# Altium schematic sheet JTAG.SchDoc(JTAG)
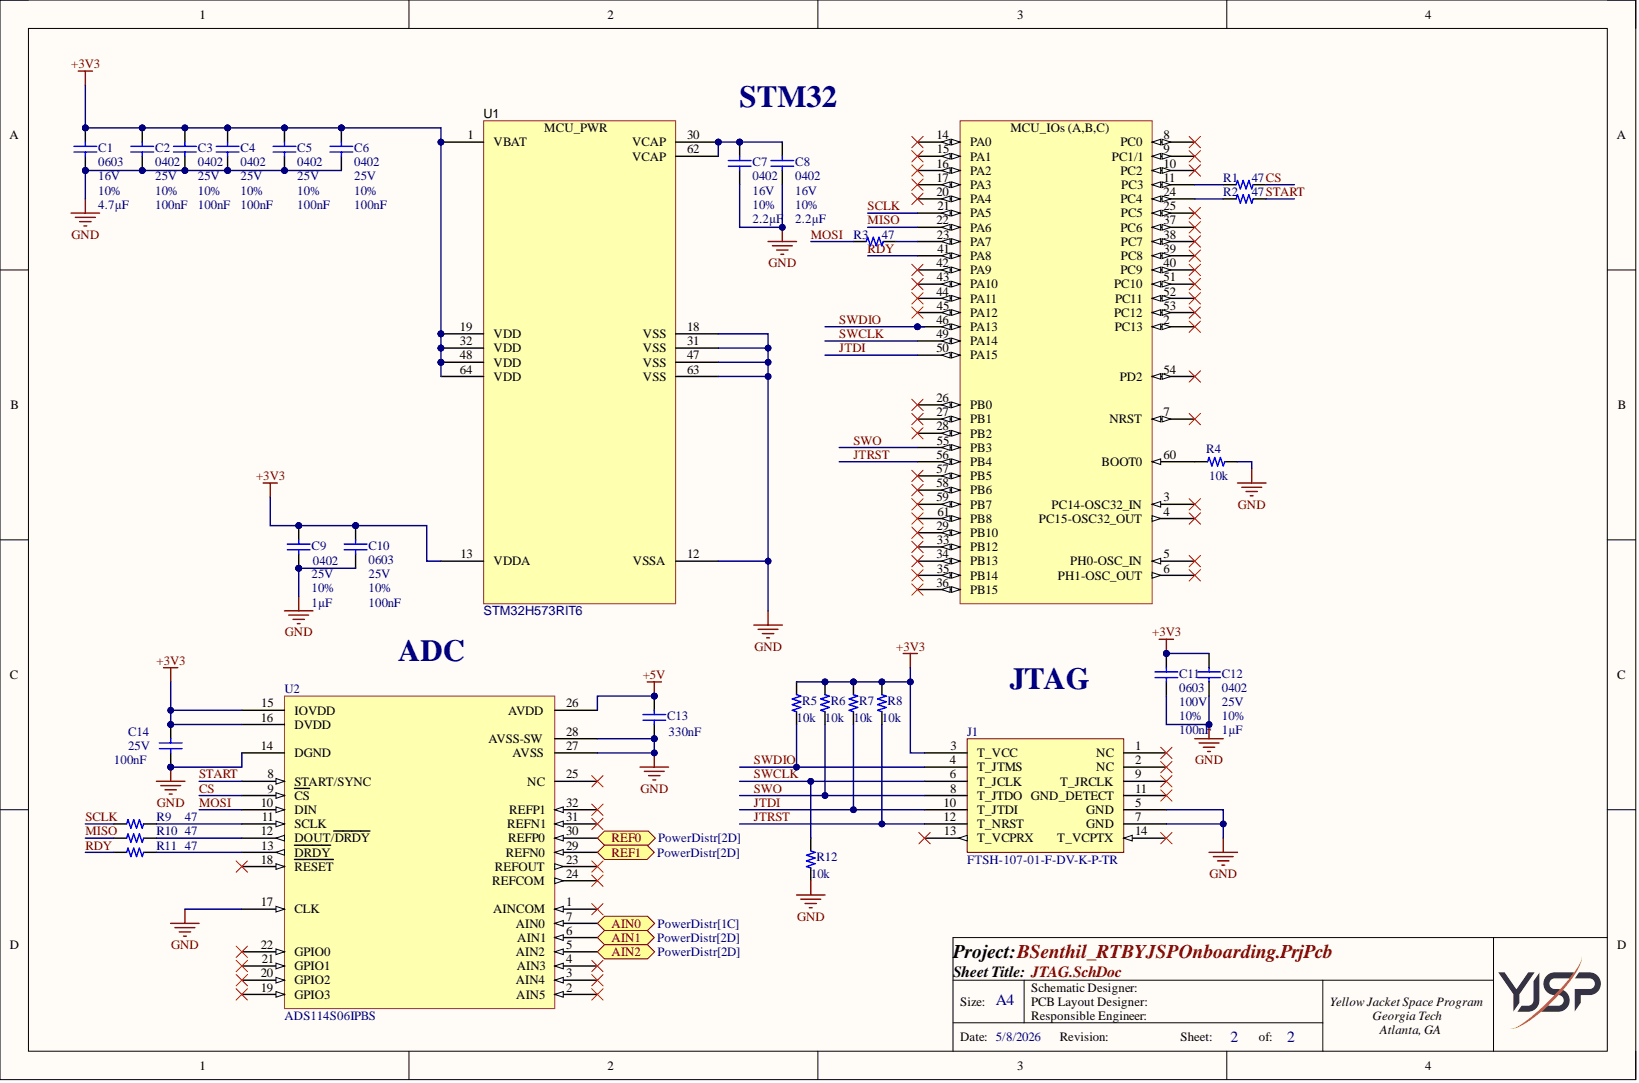
[diagram p0: JTAG.SchDoc]

## Parts
C1  Package: 0603  Tolerance: 10%  Voltage Rating: 16V  (hidden comment: GRM188Z71C475KE21J)
C2  Package: 0402  Tolerance: 10%  Voltage Rating: 25V  (hidden comment: GRM155R71E104KE14J)
C3  Package: 0402  Tolerance: 10%  Voltage Rating: 25V  (hidden comment: GRM155R71E104KE14J)
C4  Package: 0402  Tolerance: 10%  Voltage Rating: 25V  (hidden comment: GRM155R71E104KE14J)
C5  Package: 0402  Tolerance: 10%  Voltage Rating: 25V  (hidden comment: GRM155R71E104KE14J)
C6  Package: 0402  Tolerance: 10%  Voltage Rating: 25V  (hidden comment: GRM155R71E104KE14J)
C7  Package: 0402  Voltage Rating: 16V  (hidden comment: GRM155R61C225KE11D)
C8  Package: 0402  Voltage Rating: 16V  (hidden comment: GRM155R61C225KE11D)
C9  Package: 0402  Tolerance: 10%  Voltage Rating: 25V  (hidden comment: GRM155C81E105KE11D)
C10  Package: 0603  Tolerance: 10%  Voltage Rating: 25V  (hidden comment: C1608X8R1E104K080AE)
C11  Package: 0603  Tolerance: 10%  Voltage Rating: 100V  (hidden comment: CL10B104KC8NNNC)
C12  Package: 0402  Tolerance: 10%  Voltage Rating: 25V  (hidden comment: GRM155C81E105KE11D)
C13  Capacitance: 330nF  (hidden comment: CGA3E3X7R1E334M080AB)
C14  Capacitance: 100nF  Voltage Rating: 25V  (hidden comment: C1608X8R1E104K080AE)
J1  FTSH-107-01-F-DV-K-P-TR
R1  (no value)
R2  (no value)
R3  (no value)
R4  Resistance: 10k
R5  Resistance: 10k
R6  Resistance: 10k
R7  Resistance: 10k
R8  Resistance: 10k
R9  (no value)
R10  (no value)
R11  (no value)
R12  Resistance: 10k
U1  STM32H573RIT6
U2  ADS114S06IPBS

## Ports
AIN0, AIN1, AIN2, REF0, REF1

## Nets
+3V3: C1.2 C2.2 C3.2 C4.2 C5.2 C6.2 C9.2 C10.2 C11.2 C12.2 C14.2 J1.3(T_VCC) R5.2 R6.2 R7.2 R8.2 U1.1(VBAT) U1.13(VDDA) U1.19(VDD) U1.32(VDD) U1.48(VDD) U1.64(VDD) U2.15(IOVDD) U2.16(DVDD)
+5V: C13.2 U2.26(AVDD)
GND: C1.1 C2.1 C3.1 C4.1 C5.1 C6.1 C7.1 C8.1 C9.1 C10.1 C11.1 C12.1 C13.1 C14.1 J1.5(GND) J1.7(GND) R4.2 R12.1 U1.12 U1.18 U1.31 U1.47 U1.63 U2.14(DGND) U2.17(CLK) U2.27(AVSS) U2.28(AVSS-SW)
AIN0: U2.7(AIN0) ; port AIN0
AIN1: U2.6(AIN1) ; port AIN1
AIN2: U2.5(AIN2) ; port AIN2
CS: R1.2 U2.9(CS)
JTDI: J1.10(T_JTDI) R7.1 U1.50
JTRST: J1.12(T_NRST) R8.1 U1.56
MISO: R10.1 U1.22
MOSI: R3.1 U2.10(DIN)
RDY: R11.1 U1.41
REF0: U2.30(REFP0) ; port REF0
REF1: U2.29(REFN0) ; port REF1
SCLK: R9.1 U1.21
START: R2.2 U2.8(START/SYNC)
SWCLK: J1.6(T_JCLK) R12.2 U1.49
SWDIO: J1.4(T_JTMS) R5.1 U1.46
SWO: J1.8(T_JTDO) R6.1 U1.55
NetC7_2: C7.2 C8.2 U1.30 U1.62
NetR1_1: R1.1 U1.11(PC3)
NetR2_1: R2.1 U1.24(PC4)
NetR3_2: R3.2 U1.23
NetR4_1: R4.1 U1.60(BOOT0)
NetR9_2: R9.2 U2.11(SCLK)
NetR10_2: R10.2 U2.12(DOUT/DRDY)
NetR11_2: R11.2 U2.13(DRDY)

Not connected: J1 1(NC) 2(NC) 9(T_JRCLK) 11(GND_DETECT) 13(T_VCPRX) 14(T_VCPTX); U1 2(PC13) 3(PC14-OSC32_IN) 4(PC15-OSC32_OUT) 5(PH0-OSC_IN) 6(PH1-OSC_OUT) 7(NRST) 8(PC0) 9(PC1/1) 10(PC2) 14 15 16 17 20 25(PC5) 26 27 28 29 33 34 35 36 37(PC6) 38(PC7) 39(PC8) 40(PC9) 42 43 44 45 51(PC10) 52(PC11) 53(PC12) 54(PD2) 57 58 59 61; U2 1(AINCOM) 2(AIN5) 3(AIN4) 4(AIN3) 18(RESET) 19(GPIO3) 20(GPIO2) 21(GPIO1) 22(GPIO0) 23(REFOUT) 24(REFCOM) 25(NC) 31(REFN1) 32(REFP1)

## Library data of the parts
C1 = CMP-001-00089-7: 4.7uF 0603 10% 16V X7R; Manufacturer: Murata; Capacitance: 4.7µF; Component Kind: Standard; Dielectric: X7R; Library Name: Yellow Jacket Space Program
C2-C6 = CMP-001-00041-14: 100nF 0402 10% 25V X7R; Manufacturer: Murata; Capacitance: 100nF; Component Kind: Standard; Dielectric: X7R; Library Name: Yellow Jacket Space Program
C7 C8 = CMP-002-000038-9: 2.2uF 0402 10% 16V X5R; Manufacturer: Murata; Capacitance: 2.2µF; Component Kind: Standard; Dielectric: X5R; Tolerance: 10%; Library Name: Yellow Jacket Space Program
C9 C12 = CMP-101-0005-5: 1uF 0402 10% 25V X6S; Manufacturer: Murata; Capacitance: 1µF; Component Kind: Standard; Dielectric: X6S; Library Name: Yellow Jacket Space Program
C10 C14 = CMP-001-00054-5: 100nF 0603 10% 25V X8R; Manufacturer: Murata; Dielectric: X7R; Component Kind: Standard; Library Name: Yellow Jacket Space Program
  C10: Capacitance: 100nF
C11 = CMP-101-0068-1: 100nF 0603 10% 100V X7R; Manufacturer: Samsung; Capacitance: 100nF; Component Kind: Standard; Dielectric: X7R; Library Name: Yellow Jacket Space Program
C13 = CMP-001-00057-5: 330nF 0603 20% 25V X7R; Manufacturer: Murata; Component Kind: Standard; Dielectric: X7R; Library Name: Yellow Jacket Space Program
J1 = CMP-00089-1: Board Connector, 14 Contact(s), 2 Row(s), Male, Straight, 0.05 inch Pitch, Surface Mount Terminal, Locking; Body Breadth: 0.135inch; Body Length: 0.35inch; Contact Pattern: RECTANGULAR; Contact Style: SQ PIN-SKT; Number Of Connectors: 1; Number of Contacts: 14; Number Of PCB Rows: 2; PCB Contact Row Spacing: 4.064mm; Plating Thickness: 3uin; Reference Standard: UL; Reliability: COMMERCIAL; Component Kind: Standard; Connector Type: BOARD CONNECTOR; Contact Finish - Mating: AU ON NI; Contact Finish - Termination: Tin (Sn) - with Nickel (Ni) barrier; Contact Gender: Male; Contact Material: Bronze; Insulation Material: Polymer; JESD-609 Code: e3; Mating Contact Pitch: 0.05inch; Mating Contact Row Spacing: 0.05inch; Mounting Option 1: LOCKING; Mounting Style: STRAIGHT; Mounting Technology: Board; Number of Rows Loaded: 2; PCB Contact Pattern: RECTANGULAR; Reach SVHC Compliant: Yes; RoHS Compliant: Yes; Terminal Pitch: 1.27mm; Termination: SMD/SMT; Library Name: Yellow Jacket Space Program
R1-R3 R9-R11 = CMP-100-0039-2: 47R 0603 1% 1/10W; Mfr_Name: Yageo; Rated Power: 100mW; Resistance: 47; Component Kind: Standard; Library Name: Yellow Jacket Space Program
R4 = CMP-009-00099-13: 10K 0603 0.1% 1/10W; Mfr_Name: Panasonic; Rated Power: 100mW; Component Kind: Standard; Library Name: Yellow Jacket Space Program
R5-R8 R12 = CMP-100-0205-1: 10k 0201 1% 1/20W; Mfr_Name: Vishay Dale; Rated Power: 50mW; Component Kind: Standard; Library Name: Yellow Jacket Space Program
U1 = CMP-00096-9: ARM® Cortex®-M33 STM32H5 Microcontroller IC 32-Bit 250MHz 2MB (2M x 8) FLASH 64-LQFP (10x10); Manufacturer: STMicroelectronics; Component Kind: Standard; Library Name: Yellow Jacket Space Program
U2 = CMP-001-0109-1: 6-Channel Single ADC Delta-Sigma 4ksps 24-bit Serial 32-Pin TQFP Tray; Manufacturer: Texas Instruments; Interface: SPI; Number of Inputs: 6; Case/Package: TFQFP; Component Kind: Standard; Max Operating Temperature: 125°C; Min Operating Temperature: -50°C; RoHS Compliant: Yes; Library Name: Yellow Jacket Space Program
